# Altium schematic sheet Power.SchDoc
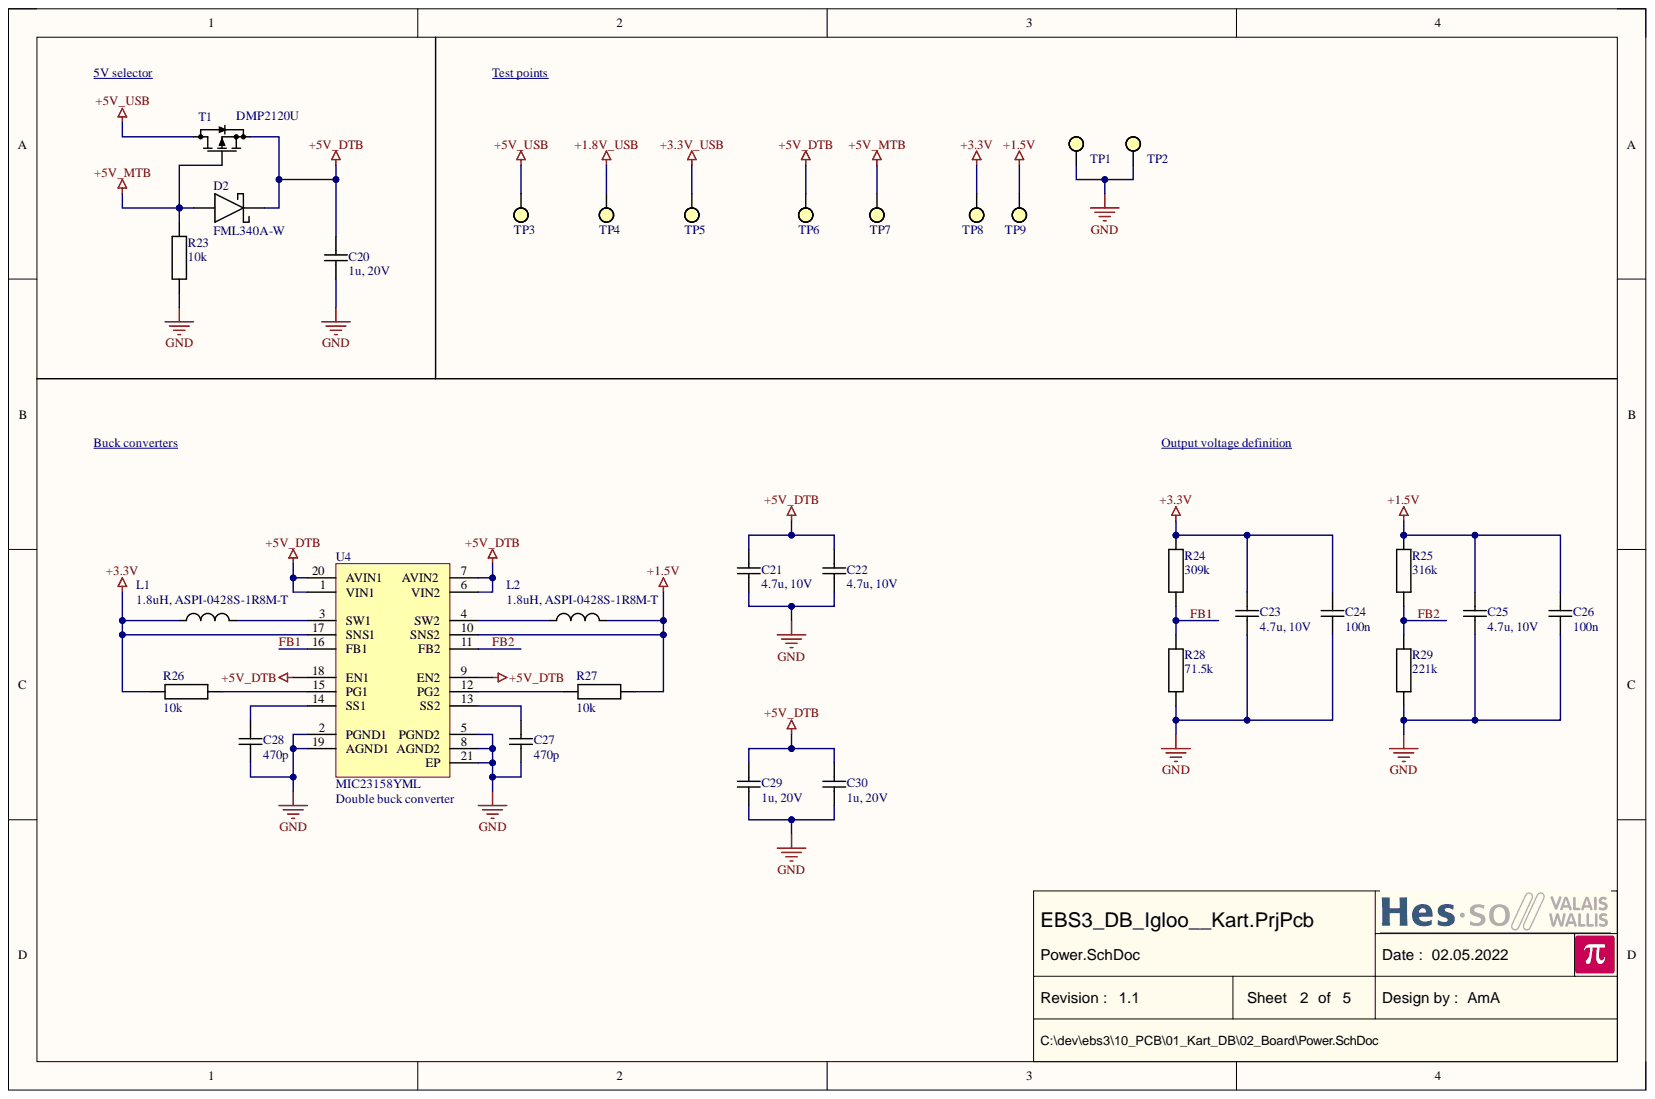
[diagram p0: Power.SchDoc]

## Parts
C20  1u, 20V  [CAPACITOR 1608 REFLOW]
C21  4.7u, 10V  [CAPACITOR 2012 REFLOW]
C22  4.7u, 10V  [CAPACITOR 2012 REFLOW]
C23  4.7u, 10V  [CAPACITOR 2012 REFLOW]
C24  100n  [CAPACITOR 1608 REFLOW]
C25  4.7u, 10V  [CAPACITOR 2012 REFLOW]
C26  100n  [CAPACITOR 1608 REFLOW]
C27  470p  [CAPACITOR 1608 REFLOW]
C28  470p  [CAPACITOR 1608 REFLOW]
C29  1u, 20V  [CAPACITOR 2012 REFLOW]
C30  1u, 20V  [CAPACITOR 2012 REFLOW]
D2  FML340A-W  [DIODE DO-214AC (SMA)]
L1  1.8uH, ASPI-0428S-1R8M-T  [ASPI-0428S]
L2  1.8uH, ASPI-0428S-1R8M-T  [ASPI-0428S]
R23  10k  [RESISTOR 1608 REFLOW]
R24  309k  [RESISTOR 1608 REFLOW]
R25  316k  [RESISTOR 1608 REFLOW]
R26  10k  [RESISTOR 1608 REFLOW]
R27  10k  [RESISTOR 1608 REFLOW]
R28  71.5k  [RESISTOR 1608 REFLOW]
R29  221k  [RESISTOR 1608 REFLOW]
T1  DMP2120U  [SOT23 P0.95 C1.6x3.0 H1.3]
TP1  ARWIN S2761-46R  [Test Point ARWIN S2761-46R]  (value not drawn)
TP2  ARWIN S2761-46R  [Test Point ARWIN S2761-46R]  (value not drawn)
TP3  (no value)  [Pad D1.5]  (no BOM)
TP4  (no value)  [Pad D1.5]  (no BOM)
TP5  (no value)  [Pad D1.5]  (no BOM)
TP6  (no value)  [Pad D1.5]  (no BOM)
TP7  (no value)  [Pad D1.5]  (no BOM)
TP8  ARWIN S2761-46R  [Test Point ARWIN S2761-46R]  (value not drawn)
TP9  ARWIN S2761-46R  [Test Point ARWIN S2761-46R]  (value not drawn)
U4  MIC23158YML  [QFN20 P0.5 T2.65x1.65 C4.0x3.0 H0.9]

## Nets
+1.5V: C25.2 C26.2 L2.2 R25.2 R27.1 TP9.1 U4.10(SNS2)
+1.8V_USB: TP4.1
+3.3V: C23.2 C24.2 L1.1 R24.2 R26.1 TP8.1 U4.17(SNS1)
+3.3V_USB: TP5.1
+5V_DTB: C20.2 C21.2 C22.2 C29.2 C30.2 D2.1 T1.2 TP6.1 U4.1(VIN1) U4.6(VIN2) U4.7(AVIN2) U4.9(EN2) U4.18(EN1) U4.20(AVIN1)
+5V_MTB: D2.2 R23.2 T1.1 TP7.1
+5V_USB: T1.3 TP3.1
GND: C20.1 C21.1 C22.1 C23.1 C24.1 C25.1 C26.1 C27.2 C28.1 C29.1 C30.1 R23.1 R28.1 R29.1 TP1.1 TP2.1 U4.2(PGND1) U4.5(PGND2) U4.8(AGND2) U4.19(AGND1) U4.21(EP)
FB1: R24.1 R28.2 U4.16(FB1)
FB2: R25.1 R29.2 U4.11(FB2)
NetC27_1: C27.1 U4.13(SS2)
NetC28_2: C28.2 U4.14(SS1)
NetL1_2: L1.2 U4.3(SW1)
NetL2_1: L2.1 U4.4(SW2)
NetR26_2: R26.2 U4.15(PG1)
NetR27_2: R27.2 U4.12(PG2)

## Library data of the parts
C20-C30 = Capacitor: Component Kind: Standard; Description: Capacitor; Library Name: Capacitor.IntLib
  C20 C29 C30: Value: 1u, 20V
  C21-C23 C25: Value: 4.7u, 10V
  C24 C26: Value: 100n
  C27 C28: Value: 470p
D2 = DIODE SCHOTTKY: Diode Schottky generic 2 Leads; Component Kind: Standard; Library Name: Diode.IntLib; Value: FML340A-W
L1 L2 = Inductor: Component Kind: Standard; Description: Inductor; Library Name: Inductor.IntLib; Value: 1.8uH, ASPI-0428S-1R8M-T
R23-R29 = Resistor: Component Kind: Standard; Description: Resistor; Library Name: Resistor.IntLib
  R23 R26 R27: Value: 10k
  R24: Value: 309k
  R25: Value: 316k
  R28: Value: 71.5k
  R29: Value: 221k
T1 = MOS-P SOT23 G-S-D: Generic MOS-P; Component Kind: Standard; Library Name: Transistor.IntLib; Value: DMP2120U
TP1 TP2 TP8 TP9 = Test Point: Component Kind: Standard; Description: Test Point; Library Name: Testpoint.IntLib; Value: ARWIN S2761-46R
TP3-TP7 = Pad: Description: Pad; Library Name: Testpoint.IntLib
U4 = MIC23158YML: 3 MHz PWM Dual 2A Buck Regulator with HyperLight Load and Power Good; Component Kind: Standard; Library Name: VoltageConverter.IntLib; Value: MIC23158YML
